# Altium schematic sheet Precision Voltage References.SchDoc
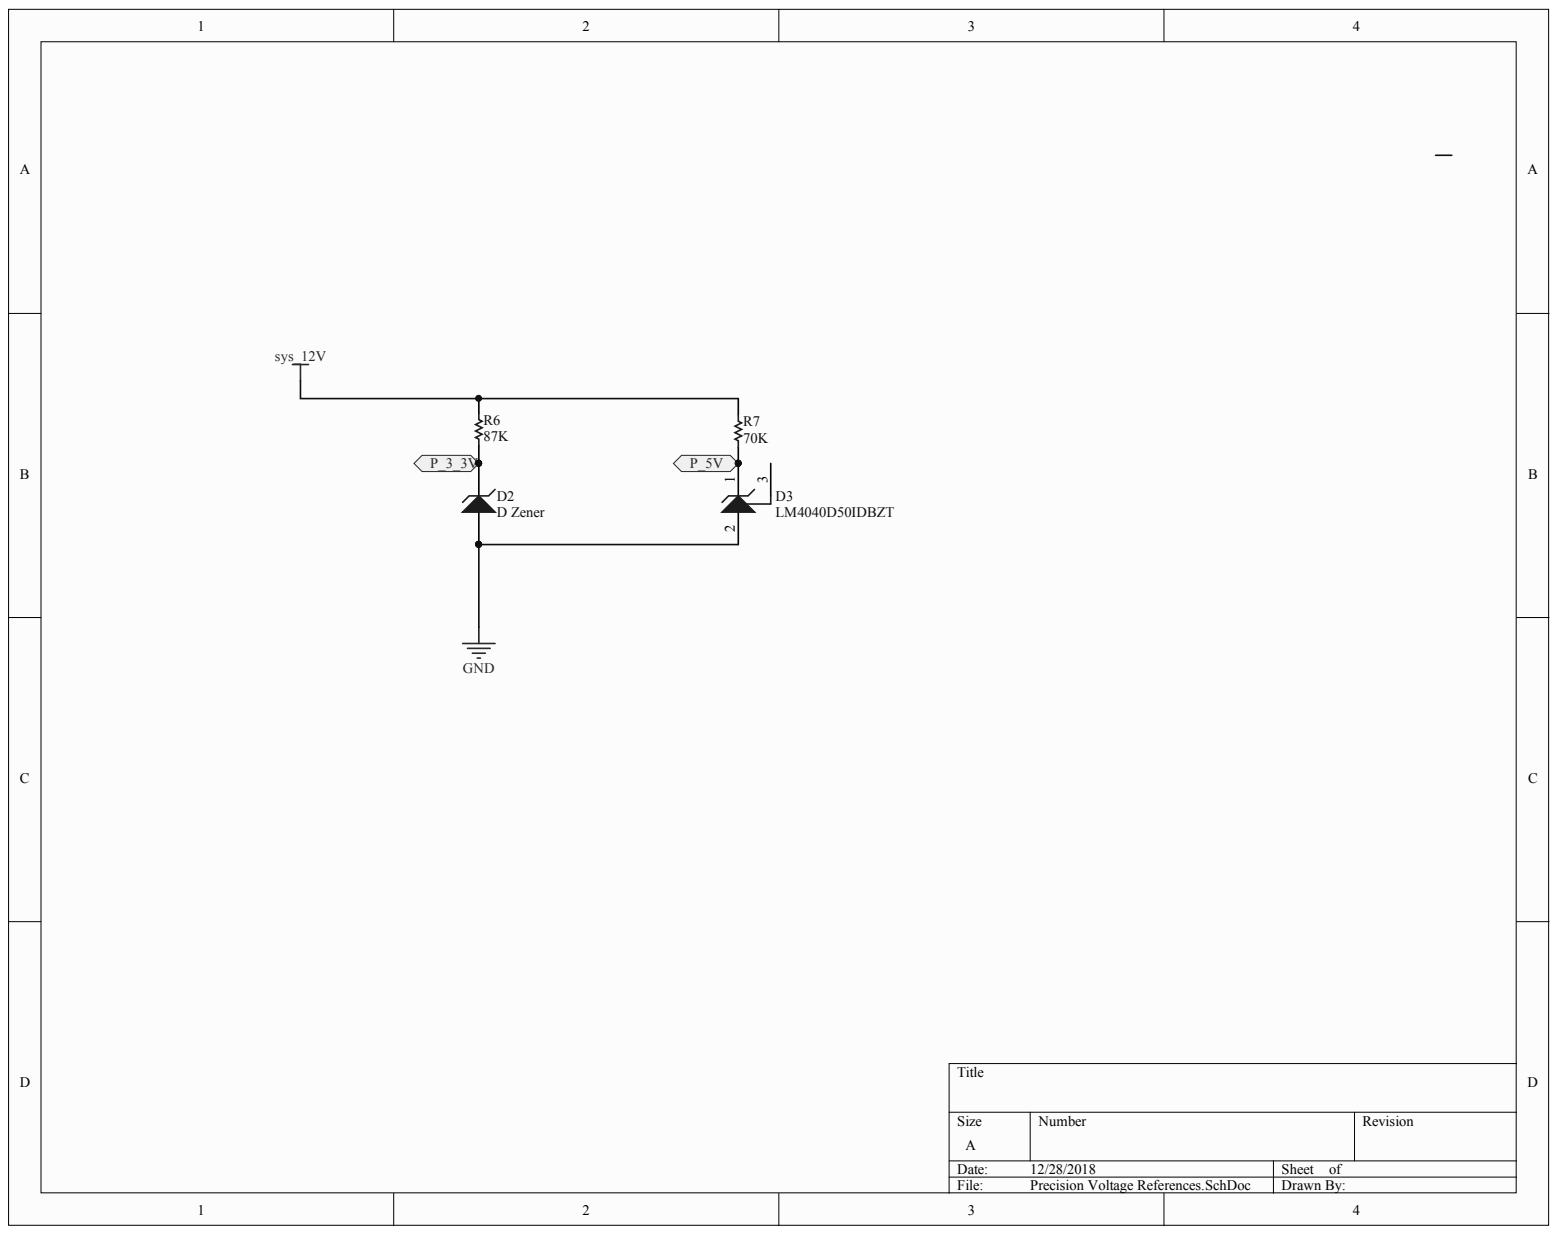
[diagram p0: Precision Voltage References.SchDoc]

## Parts
D2  D Zener  [PCB-w6hj17rbu74or7s17m81-1]
D3  LM4040D50IDBZT  [TI-DBZ3_N]
R6  87K  [PCB-4wb2e9ffedelayu61z6k-1]
R7  70K  [PCB-4wb2e9ffedelayu61z6k-1]

## Ports
P_3_3V, P_5V

## Nets
GND: D2.1 D3.2
SYS_12V: R6.2 R7.2
P_3_3V: D2.2 R6.1 ; port P_3_3V
P_5V: D3.1 R7.1 ; port P_5V
N00002:

Not connected: D3 3

## Library data of the parts
D2 = CMP-85a54efe2b7e5a62-1: V-Ref Precision 3.3V 5mA 3-Pin SOT-23 T/R; Lead-Free Status: Lead Free; Component Kind: Standard; Library Name: CommunityVault; Octopart URL: https://octopart.com/zxre330asa-7-diodes+inc.-38898621
D3 = CMP-19302333-2: Precision Micropower Shunt Voltage Reference, 1% accuracy, 5 V, 15 ppm / degC, 15 mA, -40 to 85 degC, 3-pin SOT-23 (DBZ), Green (RoHS & no Sb/Br); Lead-Free Status: Lead Free; Output Voltage: 5.0 V; Temperature Coefficient: 150.0 ppm/°C; Case/Package: SOT-23; Component Kind: Standard; Library Name: CommunityVault; Lifecycle Status: Active; Manufacturer Part Number: LM4040D50IDBZT; Mounting Style: Surface Mount; Number of Pins: 3; OctopartID: c979007f329616be; Octopart Url: https://octopart.com/lm4040d50idbzt-texas+instruments-843401; Packaging: Reel; REACH SVHC Compliance: No SVHC; RoHS: Compliant
R6 R7 = CMP-492c836b26da96eb-1: Res Metal Oxide 560 Ohm 5% 0.5W(1/2W) ?}350ppm/? Conformal Coated AXL Bulk; Power Rating: 500 mW; Temperature Coefficient: -350 ppm/°C to 350 ppm/°C; Voltage Rating (DC): 300 V; Component Kind: Standard; Library Name: CommunityVault; Lifecycle Status: Not Listed by Manufacturer; Mounting Style: Axial; OctopartID: 492c836b26da96eb; Octopart URL: https://octopart.com/erg12sj561-panasonic-55397008; REACH SVHC Compliance: No SVHC
